# Altium schematic sheet alimentation.SchDoc
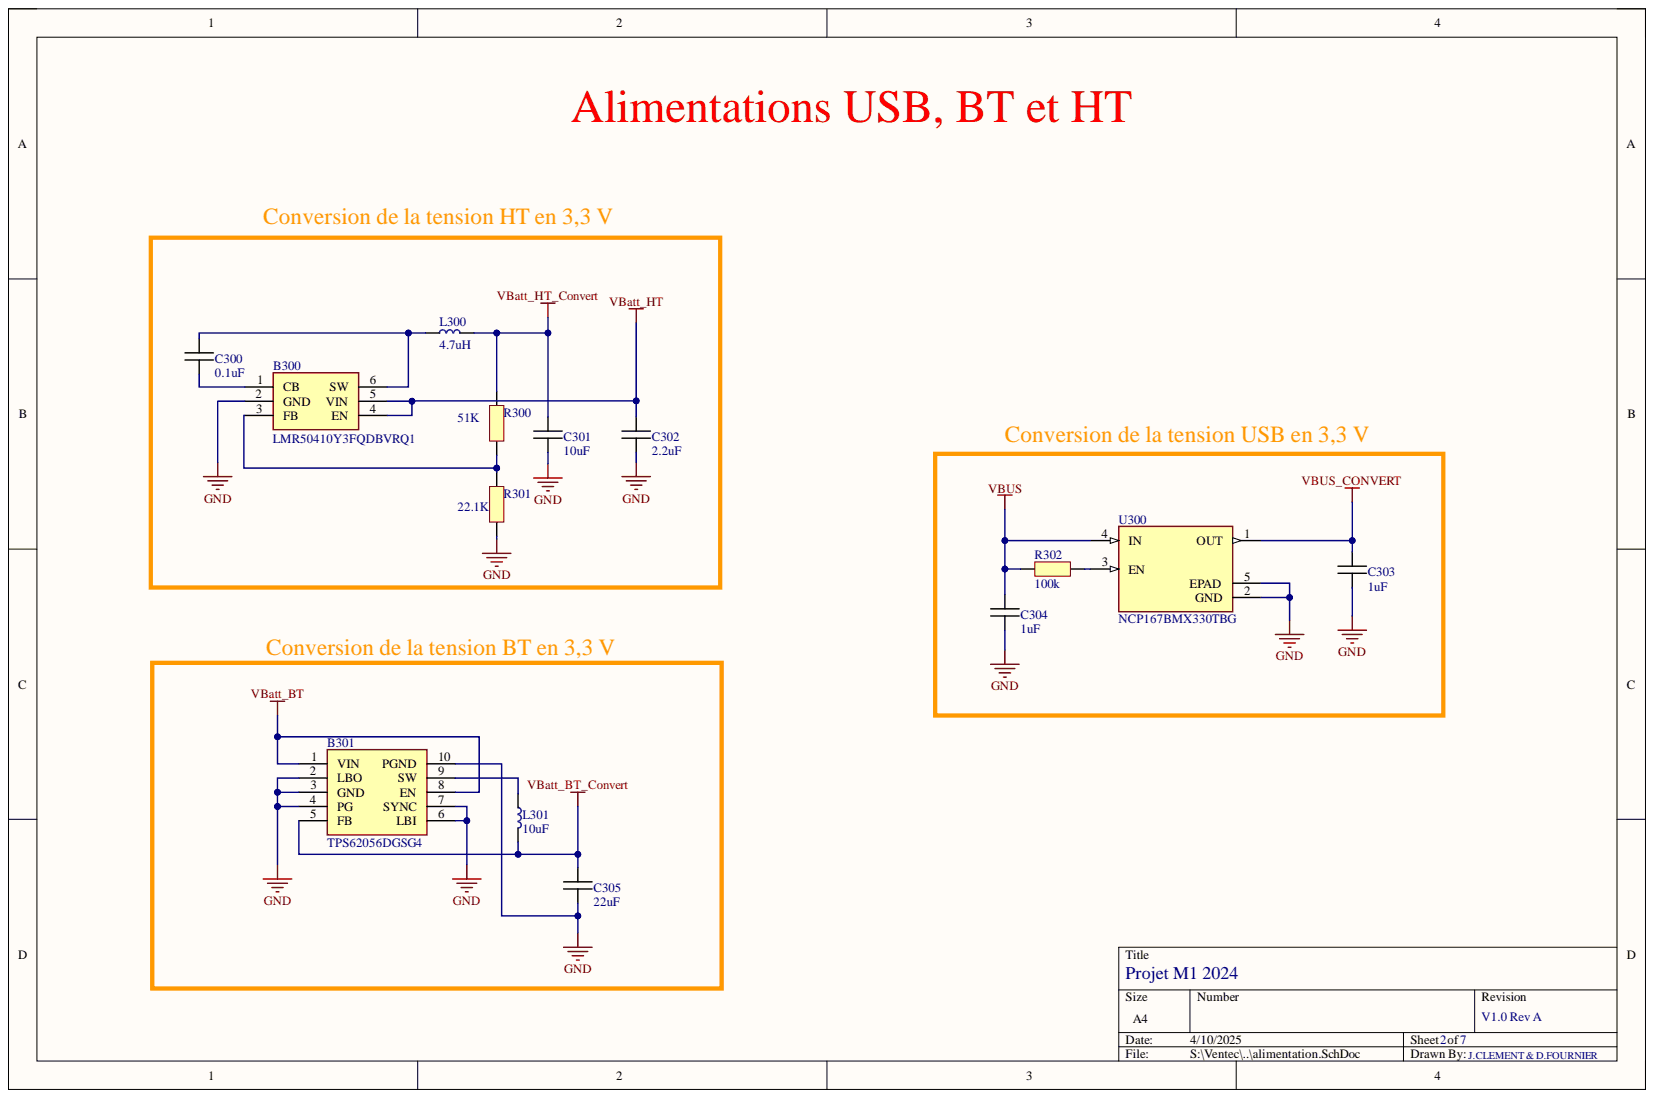
[diagram p0: alimentation.SchDoc]

## Parts
B300  LMR50410Y3FQDBVRQ1  [SOT95P280X145-6N]
B301  TPS62056DGSG4  [SOP50P490X110-10N]
C300  0.1uF  [CAPC1005X06N]
C301  10uF  [CAPC1005X06N]
C302  2.2uF  [CAPC1005X06N]
C303  1uF  [CAPC1005X06N]
C304  1uF  [CAPC1005X06N]
C305  22uF  [CAPC1005X06N]
L300  4.7uH  [LQPC1005X06N]
L301  10uF  [LQPC1005X06N]
R300  51K  [RESC1005X06N]
R301  22.1K  [RESC1005X06N]
R302  100k  [RESC1005X06N]
U300  NCP167BMX330TBG  [NCP167BMX330TBG]

## Nets
GND: B300.2(GND) B301.2(LBO) B301.3(GND) B301.4(PG) B301.6(LBI) B301.7(SYNC) B301.10(PGND) C301.2 C302.2 C303.2 C304.2 C305.2 R301.1 U300.2(GND) U300.5(EPAD)
VBatt_BT: B301.1(VIN) B301.8(EN)
VBatt_BT_Convert: B301.5(FB) C305.1 L301.2
VBatt_HT: B300.4(EN) B300.5(VIN) C302.1
VBatt_HT_Convert: C301.1 L300.2 R300.2
VBUS: C304.1 R302.2 U300.4(IN)
VBUS_CONVERT: C303.1 U300.1(OUT)
NetB300_1: B300.1(CB) C300.2
NetB300_3: B300.3(FB) R300.1 R301.2
NetB300_6: B300.6(SW) C300.1 L300.1
NetB301_9: B301.9(SW) L301.1
NetR302_1: R302.1 U300.3(EN)

## Library data of the parts
B300 = LMR50410Y3FQDBVRQ1: Régulateurs de tension de commutation Automotive qualified SIMPLE SWITCHER 4-V to 36-V, 1-A synchronous step-down converter 6-SOT-23 -40 to 150; Manufacturer_Name: Texas Instruments; Component Kind: Standard; Library Name: SCHLibrary.SchLib; Manufacturer_Part_Number: LMR50410Y3FQDBVRQ1; Mouser Part Number: 595-R50410Y3FQDBVRQ1
B301 = TPS62056DGSG4: TEXAS INSTRUMENTS - TPS62056DGSG4 - Synchronous Buck (Step Down) Switching Regulator, Fixed, 2.7V-10Vin, 3.3V/0.8A out, 850kHz, VSSOP-10; Component Kind: Standard; Library Name: SCHLibrary.SchLib; Manufacturer_Name: Texas Instruments; Manufacturer_Part_Number: TPS62056DGSG4; Mouser Part Number: 595-TPS62056DGSG4
C300-C305 = Capacitor: Component Kind: Standard; Library Name: SCHLibrary.SchLib
L300 L301 = Bobine: Component Kind: Standard; Library Name: SCHLibrary.SchLib
R300-R302 = Resistor: Component Kind: Standard; Library Name: SCHLibrary.SchLib
U300 = NCP167BMX330TBG: Régulateurs de tension LDO LDO 700 MA ULTRA-LOW NOISE 3.3V; Component Kind: Standard; Library Name: SCHLibrary.SchLib
